# Altium schematic sheet dbpq 1.0.SchDoc
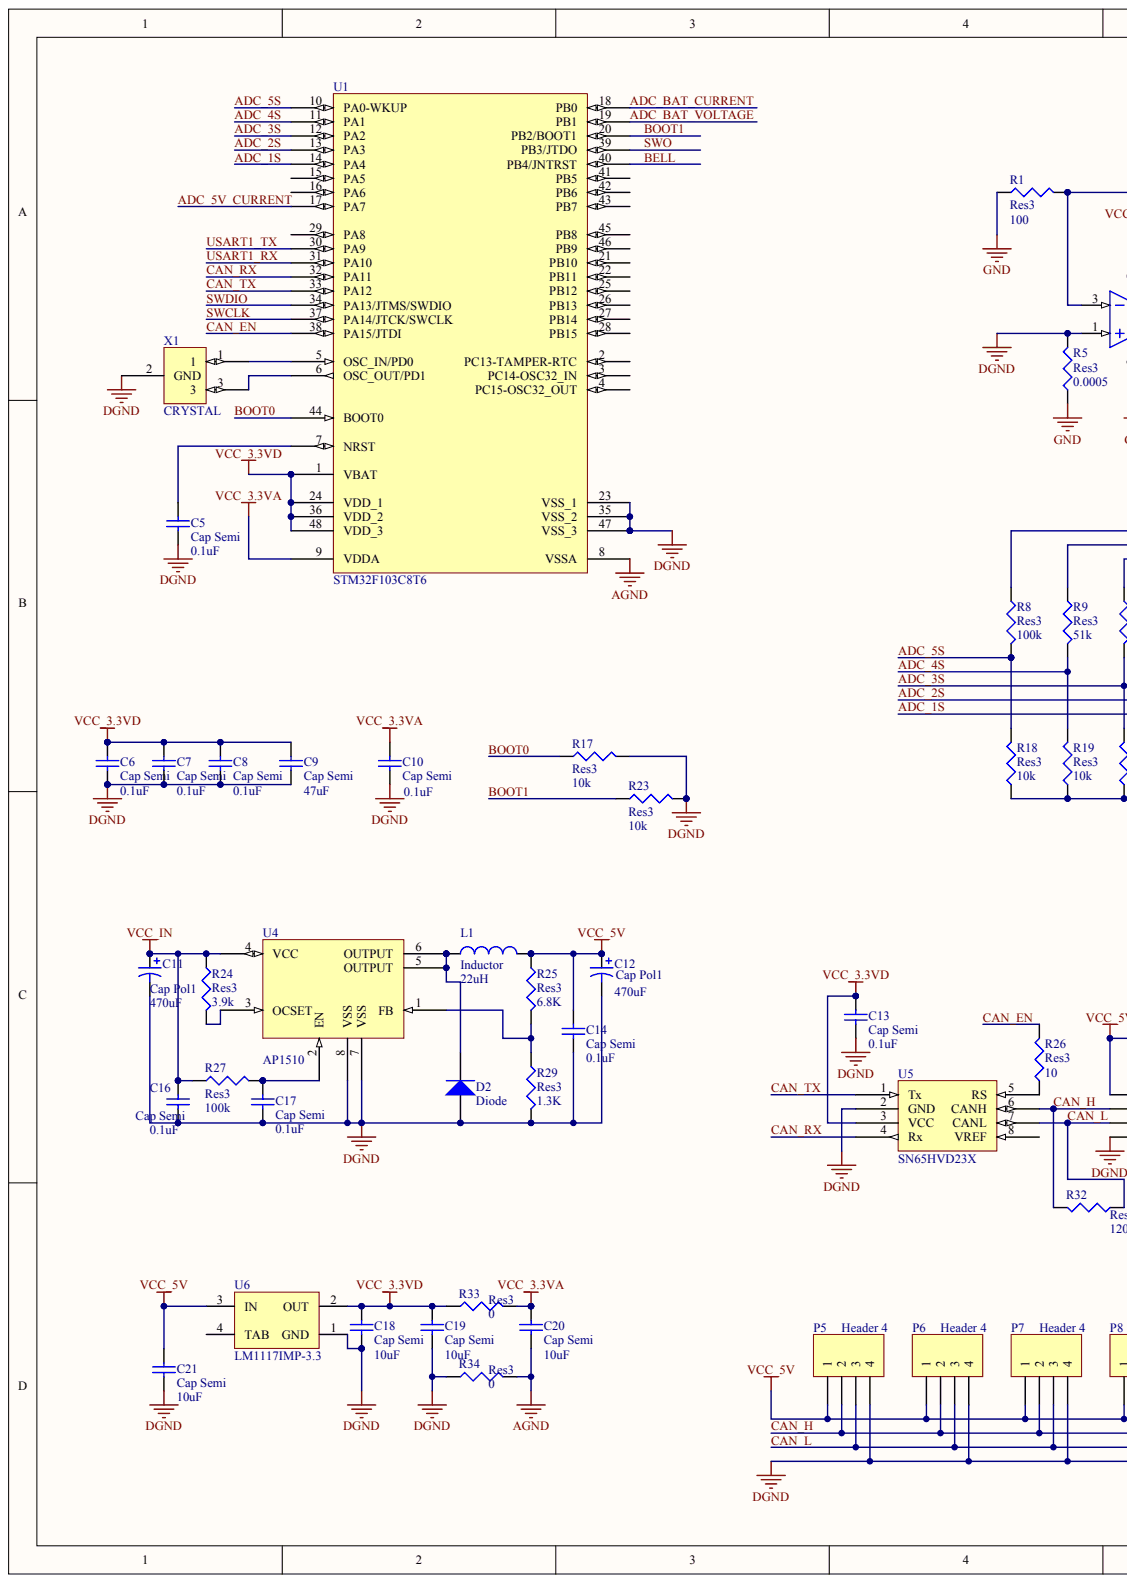
[diagram p0: dbpq 1.0.SchDoc, left]
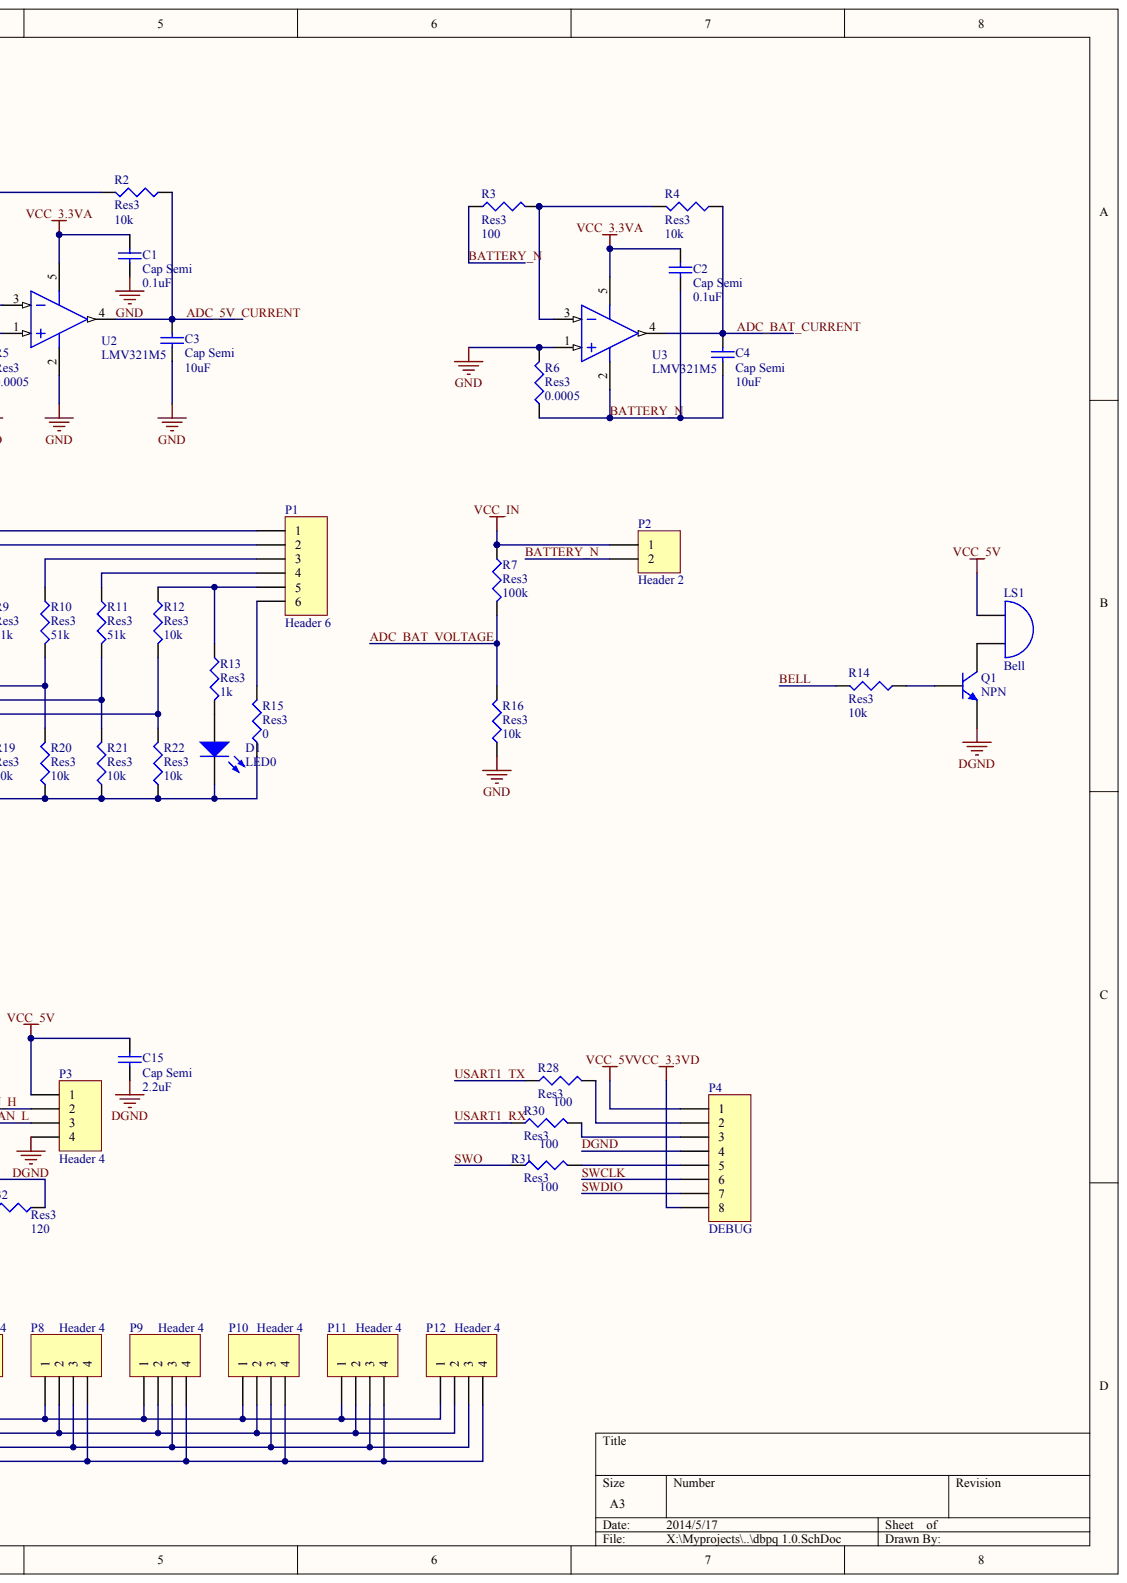
[diagram p1: dbpq 1.0.SchDoc, right]

## Parts
C1  Cap Semi  value 0.1uF
C2  Cap Semi  value 0.1uF
C3  Cap Semi  value 10uF
C4  Cap Semi  value 10uF
C5  Cap Semi  value 0.1uF
C6  Cap Semi  value 0.1uF
C7  Cap Semi  value 0.1uF
C8  Cap Semi  value 0.1uF
C9  Cap Semi  value 47uF
C10  Cap Semi  value 0.1uF
C11  Cap Pol1  value 470uF
C12  Cap Pol1  value 470uF
C13  Cap Semi  value 0.1uF
C14  Cap Semi  value 0.1uF
C15  Cap Semi  value 2.2uF
C16  Cap Semi  value 0.1uF
C17  Cap Semi  value 0.1uF
C18  Cap Semi  value 10uF
C19  Cap Semi  value 10uF
C20  Cap Semi  value 10uF
C21  Cap Semi  value 10uF
D1  LED0
D2  Diode
L1  Inductor  value 22uH
LS1  Bell
P1  Header 6
P2  Header 2
P3  Header 4
P4  DEBUG
P5  Header 4
P6  Header 4
P7  Header 4
P8  Header 4
P9  Header 4
P10  Header 4
P11  Header 4
P12  Header 4
Q1  NPN
R1  Res3  value 100
R2  Res3  value 10k
R3  Res3  value 100
R4  Res3  value 10k
R5  Res3  value 0.0005
R6  Res3  value 0.0005
R7  Res3  value 100k
R8  Res3  value 100k
R9  Res3  value 51k
R10  Res3  value 51k
R11  Res3  value 51k
R12  Res3  value 10k
R13  Res3  value 1k
R14  Res3  value 10k
R15  Res3  value 0
R16  Res3  value 10k
R17  Res3  value 10k
R18  Res3  value 10k
R19  Res3  value 10k
R20  Res3  value 10k
R21  Res3  value 10k
R22  Res3  value 10k
R23  Res3  value 10k
R24  Res3  value 3.9k
R25  Res3  value 6.8K
R26  Res3  value 10
R27  Res3  value 100k
R28  Res3  value 100
R29  Res3  value 1.3K
R30  Res3  value 100
R31  Res3  value 100
R32  Res3  value 120
R33  Res3  value 0
R34  Res3  value 0
U1  STM32F103C8T6
U2  LMV321M5
U3  LMV321M5
U4  AP1510
U5  SN65HVD23X
U6  LM1117IMP-3.3
X1  CRYSTAL

## Nets
AGND: C20.2 R34.2 U1.8(VSSA)
GND: C1.2 C3.2 R1.1 R5.1 R6.2 R16.1 U2.2 U3.1
VCC_3.3VA: C1.1 C2.1 C10.2 C20.1 R33.2 U1.9(VDDA) U2.5 U3.5
VCC_3.3VD: C6.2 C7.2 C8.2 C9.2 C13.2 C18.1 C19.1 P4.8 R33.1 U1.1(VBAT) U1.24(VDD_1) U1.36(VDD_2) U1.48(VDD_3) U5.3(VCC) U6.2(OUT)
VCC_5V: C12.1 C14.2 C15.1 C21.1 L1.2 LS1.1 P3.1 P4.1 P5.1 P6.1 P7.1 P8.1 P9.1 P10.1 P11.1 P12.1 R25.1 U6.3(IN)
VCC_IN: C11.1 C16.2 P2.1 R7.1 R24.1 R27.1 U4.4(VCC)
ADC_1S: R12.2 R22.2 U1.14(PA4)
ADC_2S: R11.2 R21.2 U1.13(PA3)
ADC_3S: R10.2 R20.2 U1.12(PA2)
ADC_4S: R9.2 R19.2 U1.11(PA1)
ADC_5S: R8.2 R18.2 U1.10(PA0-WKUP)
ADC_5V_CURRENT: C3.1 R2.1 U1.17(PA7) U2.4
ADC_BAT_CURRENT: C4.1 R4.1 U1.18(PB0) U3.4
ADC_BAT_VOLTAGE: R7.2 R16.2 U1.19(PB1)
BATTERY_N: C2.2 C4.2 P2.2 R3.1 R6.1 U3.2
BELL: R14.2 U1.40(PB4/JNTRST)
BOOT0: R17.1 U1.44(BOOT0)
BOOT1: R23.1 U1.20(PB2/BOOT1)
CAN_EN: R26.2 U1.38(PA15/JTDI)
CAN_H: P3.2 P5.2 P6.2 P7.2 P8.2 P9.2 P10.2 P11.2 P12.2 R32.2 U5.6(CANH)
CAN_L: P3.3 P5.3 P6.3 P7.3 P8.3 P9.3 P10.3 P11.3 P12.3 R32.1 U5.7(CANL)
CAN_RX: U1.32(PA11) U5.4(Rx)
CAN_TX: U1.33(PA12) U5.1(Tx)
DGND: C5.2 C6.1 C7.1 C8.1 C9.1 C10.1 C11.2 C12.2 C13.1 C14.1 C15.2 C16.1 C17.1 C18.2 C19.2 C21.2 D2.1 P3.4 P4.4 P5.4 P6.4 P7.4 P8.4 P9.4 P10.4 P11.4 P12.4 Q1.3 R5.2 R17.2 R23.2 R29.2 R34.1 U1.23(VSS_1) U1.35(VSS_2) U1.47(VSS_3) U2.1 U4.7(VSS) U4.8(VSS) U5.2(GND) U6.1(GND) X1.2
SWCLK: P4.6 U1.37(PA14/JTCK/SWCLK)
SWDIO: P4.7 U1.34(PA13/JTMS/SWDIO)
SWO: R31.1 U1.39(PB3/JTDO)
USART1_RX: R30.1 U1.31(PA10)
USART1_TX: R28.1 U1.30(PA9)
NetC5_1: C5.1 U1.7(NRST)
NetC17_2: C17.2 R27.2 U4.2(EN)
NetD1_1: D1.1 R13.2
NetD1_2: D1.2 R15.1 R18.1 R19.1 R20.1 R21.1 R22.1
NetD2_2: D2.2 L1.1 U4.5(OUTPUT) U4.6(OUTPUT)
NetLS1_2: LS1.2 Q1.1
NetP1_1: P1.1 R8.1
NetP1_2: P1.2 R9.1
NetP1_3: P1.3 R10.1
NetP1_4: P1.4 R11.1
NetP1_5: P1.5 R12.1 R13.1
NetP1_6: P1.6 R15.2
NetP4_2: P4.2 R28.2
NetP4_3: P4.3 R30.2
NetP4_5: P4.5 R31.2
NetQ1_2: Q1.2 R14.1
NetR1_2: R1.2 R2.2 U2.3
NetR3_2: R3.2 R4.2 U3.3
NetR24_2: R24.2 U4.3(OCSET)
NetR25_2: R25.2 R29.1 U4.1(FB)
NetR26_1: R26.1 U5.5(RS)
NetU1_5: U1.5(OSC_IN/PD0) X1.1
NetU1_6: U1.6(OSC_OUT/PD1) X1.3

Not connected: U1 2(PC13-TAMPER-RTC) 3(PC14-OSC32_IN) 4(PC15-OSC32_OUT) 15(PA5) 16(PA6) 21(PB10) 22(PB11) 25(PB12) 26(PB13) 27(PB14) 28(PB15) 29(PA8) 41(PB5) 42(PB6) 43(PB7) 45(PB8) 46(PB9); U5 8(VREF); U6 4(TAB)

## Library data of the parts
L1 = (no symbol name): Code_IEC: 1005; Published: 8-Jun-2000; LatestRevisionDate: 29-May-2009; LatestRevisionNote: IPC-7351 Footprint Added.; PackageReference: 0402-A; PackageDescription: Chip Inductor; Code_JEITA: 0402; Publisher: Altium Limited
R2 R4 = (no symbol name): Value: 10k; Published: 8-Jun-2000; LatestRevisionDate: 29-May-2009; LatestRevisionNote: IPC-7351 Footprint Added.; PackageReference: J1-0603; PackageDescription: Chip Resistor; Publisher: Altium Limited
U2 U3 = (no symbol name): Manufacturer: National Semiconductor; CompType: Amplifiers; PackageTopMarking: A13*XTT; PowerWise: N; Published: 4-Dec-2008; LatestRevisionDate: 7-Apr-2009; LatestRevisionNote: Sch pinout corrected.; DatasheetVersion: Jan-2009; PackageVersion: revD, Aug-2008; PackageReference: MF05A; PackageDescription: Molded SOT-23, .115 x .063 x .040 in Body, 5 Lead, .0375 in Pitch; PartNumber: LMV321M5; RoHS: O; PinCount: 5; DigiKeyPartNumber: LMV321M5CT-ND; Code_IPC: SOT23-5; ComponentLink1Description: LMV321 Datasheet; ComponentLink1URL: http://www.national.com/ds/LM/LMV321.pdf; ComponentLink2Description: LMV321 Web Page; ComponentLink2URL: http://www.national.com/pf/LM/LMV321.html; ComponentLink3Description: MF05A Package Page; ComponentLink3URL: http://www.national.com/packaging/folders/mf05a.html; Publisher: Altium Limited
U6 = (no symbol name): Manufacturer: National Semiconductor; CompType: LDO IC; PackageTopMarking: XYTT*N05B; PowerWise: N; Published: 4-Dec-2008; DatasheetVersion: Apr-2006; PackageVersion: revB, Apr-2003; PackageReference: MP04A; PackageDescription: Molded SOT-223, 6.50 x 3.56 x 1.6mm Body, 4 Lead, 2.3mm Pitch; PartNumber: LM1117IMP-3.3; RoHS: O; PinCount: 4; DigiKeyPartNumber: LM1117IMP-3.3CT-ND; Code_JEDEC: TO-261-AA; Code_IPC: SOT223-4; ComponentLink1Description: LM1117 Datasheet; ComponentLink1URL: http://www.national.com/ds/LM/LM1117.pdf; ComponentLink2Description: LM1117 Web Page; ComponentLink2URL: http://www.national.com/pf/LM/LM1117.html; ComponentLink3Description: MP04A Package Page; ComponentLink3URL: http://www.national.com/packaging/folders/mp04a.html; Publisher: Altium Limited
C1-C21 D1 D2 LS1 P1-P12 Q1 R1 R3 R5-R34 U1 U4 U5 X1 = (no symbol name)
  C1-C10 C13-C21: Published: 8-Jun-2000; LatestRevisionDate: 29-May-2009; LatestRevisionNote: IPC-7351 Footprint Added.; PackageReference: C1206; PackageDescription: Chip Capacitor
  C11 C12: Published: 8-Jun-2000; LatestRevisionDate: 17-Jul-2002; LatestRevisionNote: Re-released for DXP Platform.; PackageReference: RB7.6-15; PackageDescription: Polarized Capacitor (Radial); 2 Leads
  D1: Published: 8-Jun-2000; LatestRevisionDate: 08-Jul-2005; LatestRevisionNote: Mech Layer 1 removed.; PackageReference: LED-0; PackageDescription: LED; 2 Leads
  D2: Published: 8-Jun-2000; LatestRevisionDate: 17-Jul-2002; LatestRevisionNote: Re-released for DXP Platform.; PackageVersion: Sep-1996; PackageReference: SMC; PackageDescription: DO-214-AB/SMC; 2 C-Bend Leads; Body 7.9 x 5.9 mm, inc. leads (LxW); Code_JEDEC: DO-214-AB
  LS1: Published: 24-Mar-1999; LatestRevisionDate: 17-Jul-2002; LatestRevisionNote: Re-released for DXP Platform.; PackageReference: PIN2
  P1-P12: LatestRevisionDate: 17-Jul-2002; LatestRevisionNote: Re-released for DXP Platform.
  Q1: Published: 8-Jun-2000; LatestRevisionDate: 17-Jul-2002; LatestRevisionNote: Re-released for DXP Platform.; PackageReference: TO-226-AA; PackageDescription: TO, Flat Index; 3 In-Line, Axial Leads; Body Dia. 4.8mm; Leads 0.55 x 0.51 mm (max); Code_JEDEC: TO-226-AA
  R1 R3 R5-R34: Published: 8-Jun-2000; LatestRevisionDate: 29-May-2009; LatestRevisionNote: IPC-7351 Footprint Added.; PackageReference: J1-0603; PackageDescription: Chip Resistor
  U1: Published: 30-May-2008; DatasheetVersion: rev6, Mar-2008; PackageVersion: Mar-2008; PackageReference: LQFP48; PackageDescription: 48-Pin Low-Profile Quad Flat Pack, 7 x 7 mm Body, 0.5 mm Pitch (LQFP48); ComponentLink1Description: Manufacturer Link; ComponentLink1URL: www.st.com/stm32; ComponentLink2Description: Datasheet; ComponentLink2URL: http://www.st.com/stonline/products/literature/ds/13587.pdf
  C1-C21 D1 D2 LS1 P1-P12 Q1 R1 R3 R5-R34 U1: Publisher: Altium Limited
